# Altium schematic sheet [6]-MCU_CONNECTORS.SchDoc([6]-MCU_CONNECTORS)
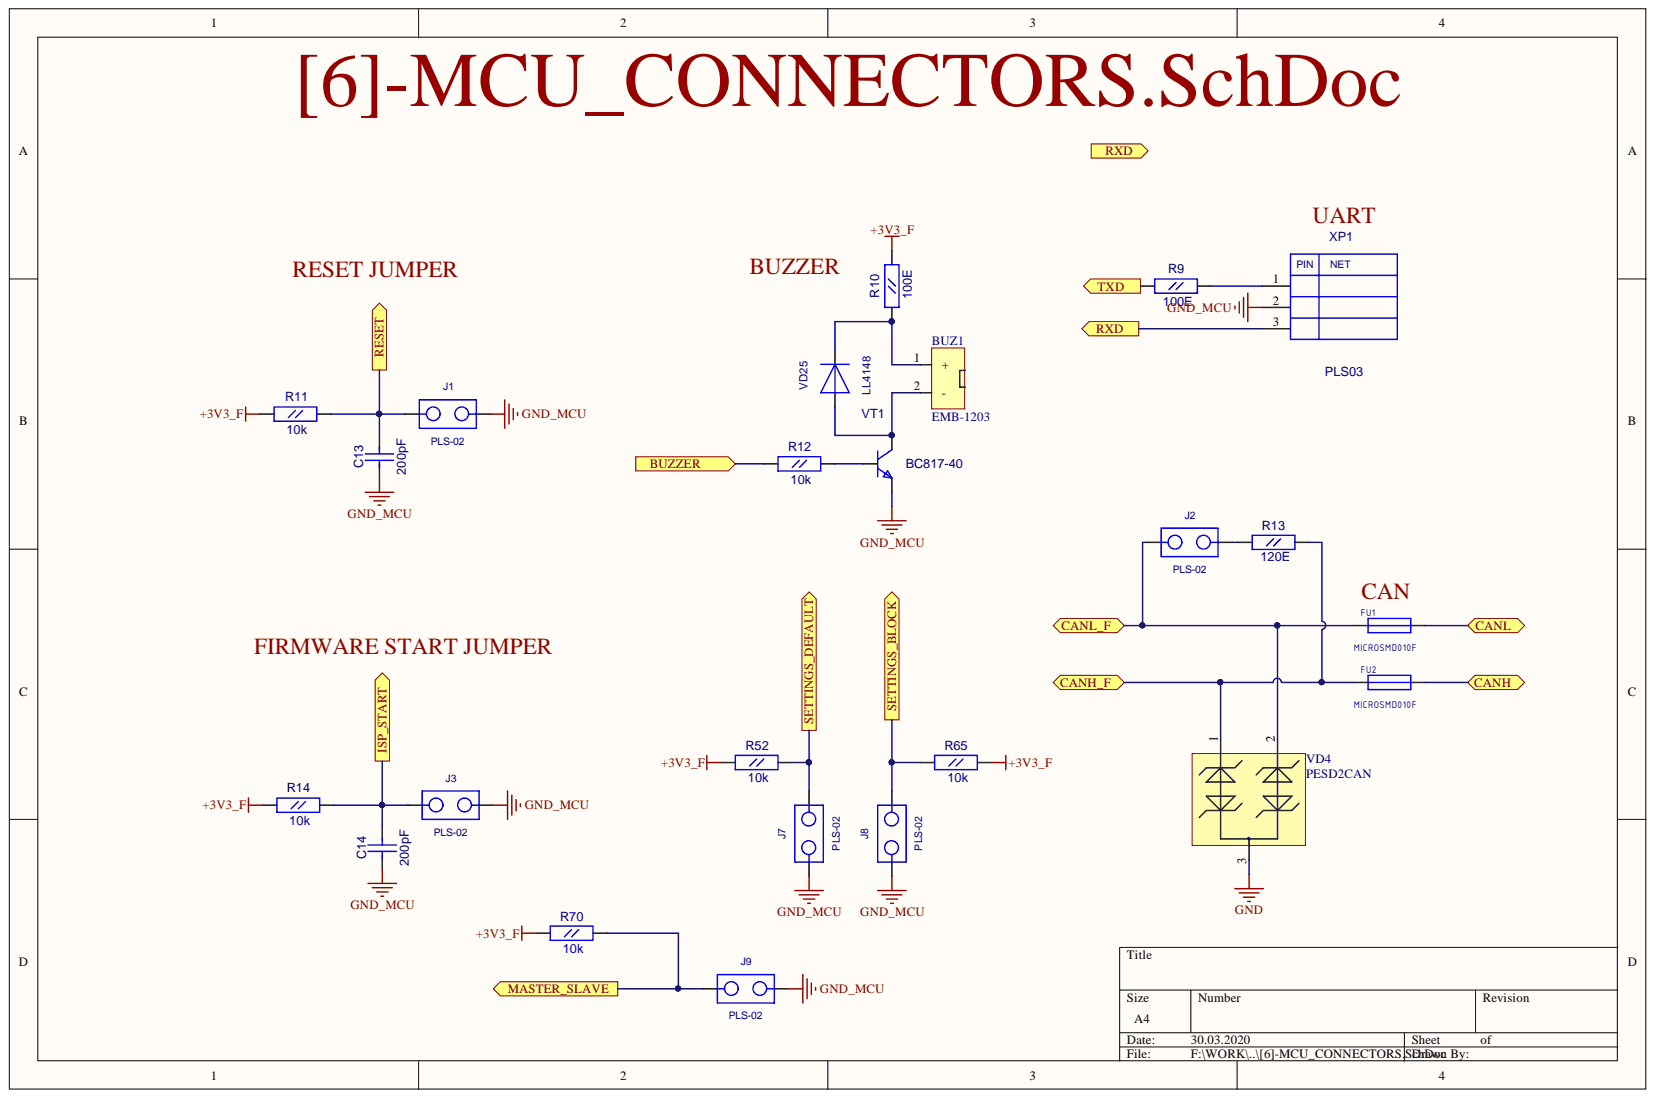
[diagram p0: [6]-MCU_CONNECTORS.SchDoc]

## Parts
BUZ1  EMB-1203  [BUZZER_12]
C13  200pF  [CC0603]
C14  200pF  [CC0603]
FU1  MICROSMD010F  [RC1210]
FU2  MICROSMD010F  [RC1210]
J1  PLS-02  [PLS-02]
J2  PLS-02  [PLS-02]
J3  PLS-02  [PLS-02]
J7  PLS-02  [PLS-02]
J8  PLS-02  [PLS-02]
J9  PLS-02  [PLS-02]
R9  100E  [RC0603]
R10  100E  [RC0603]
R11  10k  [RC0603]
R12  10k  [RC0603]
R13  120E  [RC0805]
R14  10k  [RC0603]
R52  10k  [RC0603]
R65  10k  [RC0603]
R70  10k  [RC0603]
VD4  PESD2CAN  [SOT-23(123)]
VD25  LL4148  [SOD-80]
VT1  BC817-40  [SOT-23(123)]
XP1  PLS03  [PLS-03]

## Ports
BUZZER, CANH, CANH_F, CANL, CANL_F, ISP_START, MASTER_SLAVE, RESET, RXD, SETTINGS_BLOCK, SETTINGS_DEFAULT, TXD

## Nets
+3V3_F: R10.2 R11.1 R14.1 R52.1 R65.2 R70.1
GND: VD4.3
GND_MCU: C13.1 C14.1 J1.2 J3.2 J7.1 J8.1 J9.2 VT1.2 XP1.2
BUZZER: R12.1 ; port BUZZER
CANH: FU2.2 ; port CANH
CANH_F: FU2.1 R13.2 VD4.1 ; port CANH_F
CANL: FU1.2 ; port CANL
CANL_F: FU1.1 J2.1 VD4.2 ; port CANL_F
ISP_START: C14.2 J3.1 R14.2 ; port ISP_START
MASTER_SLAVE: J9.1 R70.2 ; port MASTER_SLAVE
RESET: C13.2 J1.1 R11.2 ; port RESET
RXD: XP1.3 ; port RXD
SETTINGS_BLOCK: J8.2 R65.1 ; port SETTINGS_BLOCK
SETTINGS_DEFAULT: J7.2 R52.2 ; port SETTINGS_DEFAULT
TXD: R9.1 ; port TXD
NetBUZ1_1: BUZ1.1 R10.1 VD25.2
NetBUZ1_2: BUZ1.2 VD25.1 VT1.3
NetJ2_2: J2.2 R13.1
NetR9_2: R9.2 XP1.1
NetR12_2: R12.2 VT1.1

## Library data of the parts
BUZ1 = EMB-1203: Buzzer diameter 12mm 3V; Value: EMB-1203; Component Kind: Standard; Library Name: fizik_lib.SchLib
C13 C14 = CC0603: Value: 200pF; Description: CC0603; Component Kind: Standard; Library Name: gprs_modem_2.SchLib
FU1 FU2 = MICROSMD: Description: MICROSMD; Component Kind: Standard; Library Name: gprs_modem_2.SchLib
R9-R12 R14 R52 R65 R70 = RC0603: Description: RC0603; Component Kind: Standard; Library Name: gprs_modem_2.SchLib
  R9 R10: Value: 100E
  R11 R12 R14 R52 R65 R70: Value: 10k
R13 = RC0805: Value: 120E; Description: RC0805; Component Kind: Standard; Library Name: fizik_lib.SchLib
VD4 = PESD2CAN: TVS for CAN; Component Kind: Standard; Library Name: gprs_modem_2.SchLib
VT1 = BC846BLT1: NPN 500ma transistor; ComponentField7: Published 29-Sep-2000; Value: BC817-40; Simulation: BC846BLT1; OriginalName: BC846BLT1; Component Kind: Standard; Library Name: fizik_lib.SchLib
J1-J3 J7-J9 = PLS-02: Description: PLS-02; Component Kind: Standard
  J1 J3 J7-J9: Library Name: gprs_modem_2.SchLib
  J2: Library Name: fizik_lib.SchLib
VD25 = LL4148: Description: LL4148; Component Kind: Standard; Library Name: fizik_lib.SchLib
XP1 = PLS03: Description: PLS03; Component Kind: Standard; Library Name: fizik_lib.SchLib
